# Altium schematic sheet [1]power.SchDoc([1]power)
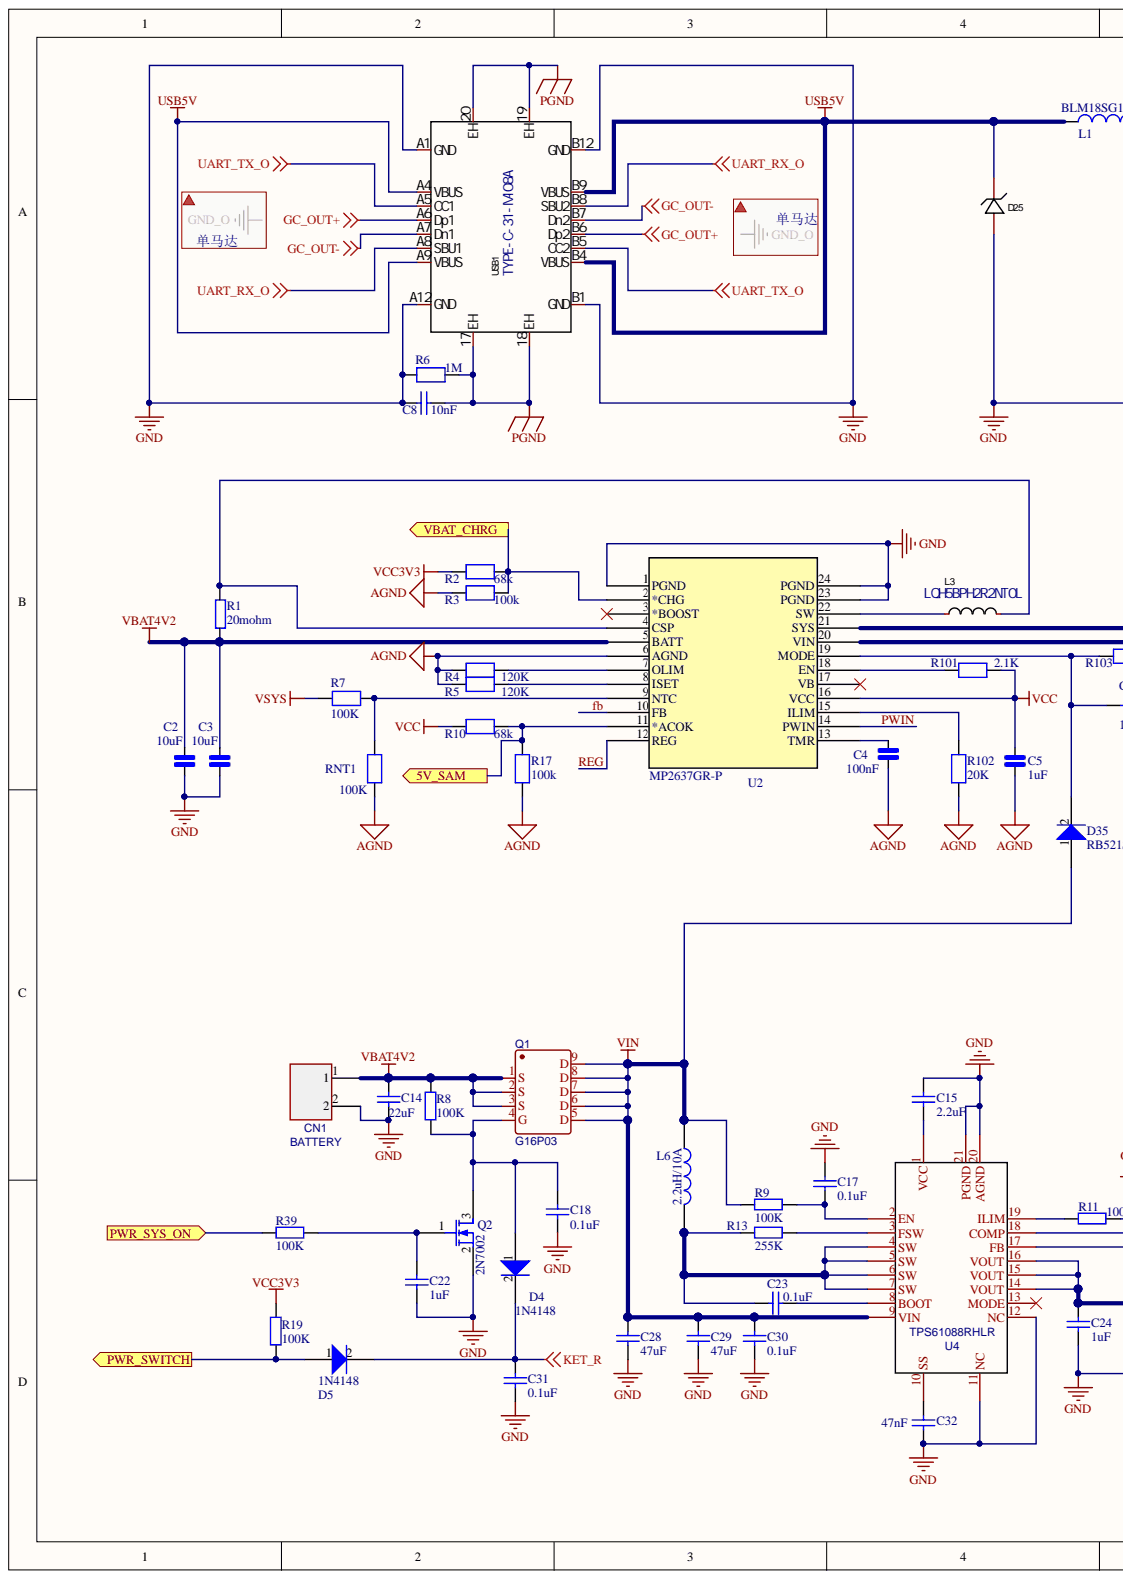
[diagram p0: [1]power.SchDoc, left]
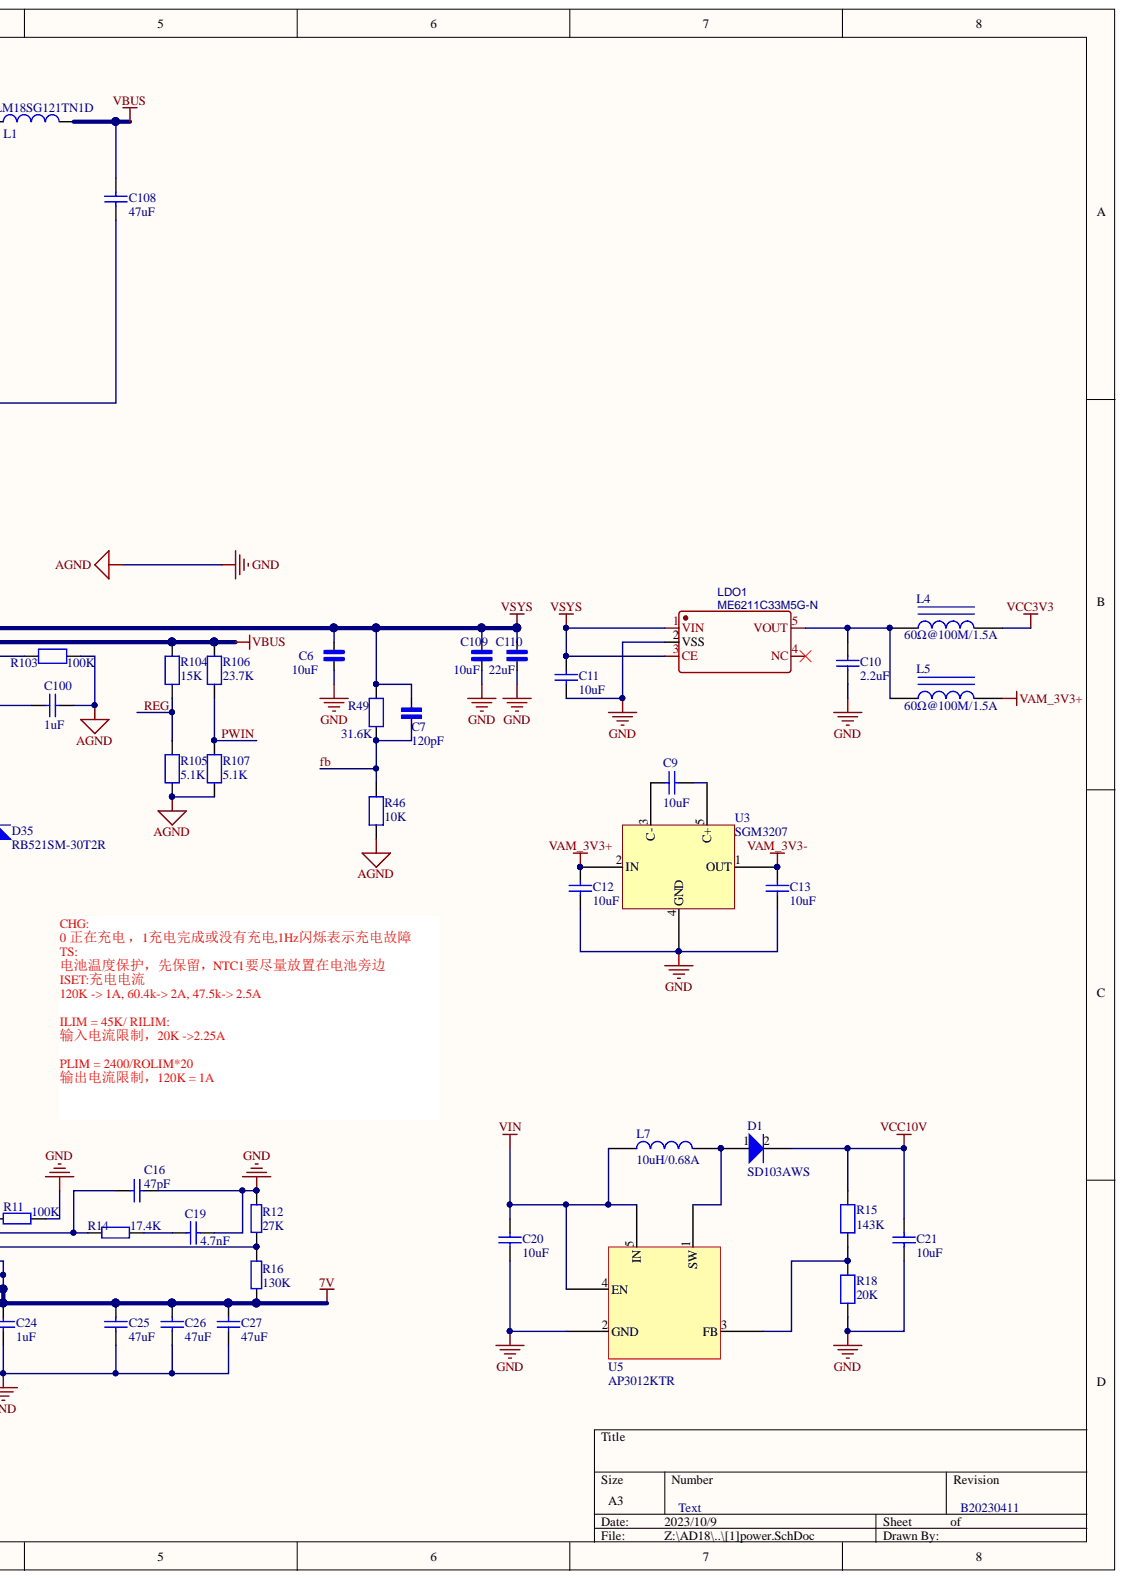
[diagram p1: [1]power.SchDoc, right]

## Parts
C2  10uF  [0603_CAP_NPOL]
C3  10uF  [0603_CAP_NPOL]
C4  100nF  [0402_CAP_NPOL]
C5  1uF  [0402_CAP_NPOL]
C6  10uF  [0603_CAP_NPOL]
C7  120pF  [0402_CAP_NPOL]
C8  10nF  [C0402]
C9  10uF  [0603]
C10  2.2uF  [C0603]
C11  10uF  [C0603]
C12  10uF  [0603]
C13  10uF  [0603]
C14  22uF  [C0805]
C15  2.2uF  [C0603]
C16  47pF  [C0402]
C17  0.1uF  [C0402]
C18  0.1uF  [C0402]
C19  4.7nF  [C0402]
C20  10uF  [C0603]
C21  10uF  [C0603]
C22  1uF  [C0402]
C23  0.1uF  [C0402]
C24  1uF  [C0402]
C25  47uF  [C1210]
C26  47uF  [C1210]
C27  47uF  [C1210]
C28  47uF  [C1210]
C29  47uF  [C1210]
C30  0.1uF  [C0402]
C31  0.1uF  [C0402]
C32  47nF  [C0402]
C100  1uF  [C0402]
C108  47uF  [C1210]
C109  10uF  [0603_CAP_NPOL]
C110  22uF  [C0805]
CN1  BATTERY  [CONN-TH_B2B-PH-K-S]
D1  SD103AWS  [SOD-323]
D4  1N4148  [SOD523]
D5  1N4148  [SOD523]
D25  TPD1E05U06DPYR  [X2SON-2_L1.0-W0.6-P0.70-RD]  (value not drawn)
D35  RB521SM-30T2R  [SOD523]
L1  BLM18SG121TN1D  [0603L]
L3  LQH5BPH2R2NT0L  [IND-SMD_L5.0-W5.0-LQH5BPN1R5NT0L]
L4  60Ω@100M/1.5A  [L0402]
L5  60Ω@100M/1.5A  [L0402]
L6  2.2uH/10A  [MWSA0603S-2R2MT]
L7  10uH/0.68A  [2520]
LDO1  ME6211C33M5G-N  [ME6211C33M5G-N]
Q1  G16P03  [G16P03]
Q2  2N7002  [SOT-23(SOT-23-3)]
R1  20mohm  [0805]
R2  68k  [0402_RES]
R3  100k  [0402_RES]
R4  120K  [0402_RES]
R5  120K  [0402_RES]
R6  1M  [R0402]
R7  100K  [0402_RES]
R8  100K  [R0402]
R9  100K  [R0402]
R10  68k  [0402_RES]
R11  100K  [R0402]
R12  27K  [R0402]
R13  255K  [R0402]
R14  17.4K  [R0402]
R15  143K  [R0402]
R16  130K  [R0402]
R17  100k  [0402_RES]
R18  20K  [R0402]
R19  100K  [R0402]
R39  100K  [R0402]
R46  10K  [0402_RES]
R49  31.6K  [0402_RES]
R101  2.1K  [0402_RES]
R102  20K  [0402_RES]
R103  100K  [0402_RES]
R104  15K  [0402_RES]
R105  5.1K  [0402_RES]
R106  23.7K  [0402_RES]
R107  5.1K  [0402_RES]
RNT1  100K  [0402_RES]
U2  MP2637GR-P  [QFN-24_MP2637_MNP]
U3  SGM3207  [SOT-23-5]
U4  TPS61088RHLR  [TPS61088RHLR]
U5  AP3012KTR  [SOT-23-5]
USB1  TYPE-C-31-M-08A  [USB-C-SMD_TYPE-C-31-M-08A]

## Ports
5V_SAM, PWR_SWITCH, PWR_SYS_ON, VBAT_CHRG

## Nets
7V: C24.1 C25.2 C26.2 C27.2 R16.1 U4.14(VOUT) U4.15(VOUT) U4.16(VOUT)
AGND: C2.2 C3.2 C4.2 C5.2 C6.2 C8.1 C10.1 C11.1 C12.2 C13.2 C14.2 C15.2 C16.2 C17.1 C18.2 C19.2 C20.2 C21.1 C22.2 C24.2 C25.1 C26.1 C27.1 C28.1 C29.1 C30.2 C31.2 C32.2 C100.2 C108.1 C109.2 C110.2 CN1.2 D25.2 LDO1.2(VSS) Q2.2 R3.1 R4.1 R5.1 R6.2 R11.2 R12.2 R17.1 R18.1 R46.2 R102.2 R103.1 R105.2 R107.2 RNT1.1 U2.1(PGND) U2.6(AGND) U2.23(PGND) U2.24(PGND) U3.4(GND) U4.11(NC) U4.12(NC) U4.20(AGND) U4.21(PGND) U5.2(GND) USB1.A1(GND) USB1.A12(GND) USB1.B1(GND) USB1.B12(GND)
GC_OUT+: USB1.A6(Dp1) USB1.B6(Dp2)
GC_OUT-: USB1.A7(Dn1) USB1.B7(Dn2)
KET_R: C31.1 D4.2 D5.2
PGND: C8.2 R6.1 USB1.17(EH) USB1.18(EH) USB1.19(EH) USB1.20(EH)
UART_RX_O: USB1.A8(SBU1) USB1.B8(SBU2)
UART_TX_O: USB1.A5(CC1) USB1.B5(CC2)
USB5V: D25.1 L1.1 USB1.A4(VBUS) USB1.A9(VBUS) USB1.B4(VBUS) USB1.B9(VBUS)
VAM_3V3+: C12.1 L5.2 U3.2(IN)
VAM_3V3-: C13.1 U3.1(OUT)
VBAT4V2: C2.1 C3.1 C14.1 CN1.1 Q1.1(S) Q1.2(S) Q1.3(S) R1.1 R8.2 U2.5(BATT)
VBUS: C108.2 L1.2 R104.1 R106.1 U2.20(VIN)
VCC: C5.1 R10.1 R101.2 U2.16(VCC)
VCC3V3: L4.2 R2.1 R19.2
VCC10V: C21.2 D1.2 R15.2
VIN: C20.1 C28.2 C29.2 C30.1 D35.1 L6.2 L7.2 Q1.5(D) Q1.6(D) Q1.7(D) Q1.8(D) Q1.9(D) R9.1 U4.9(VIN) U5.4(EN) U5.5(IN)
VSYS: C6.1 C7.2 C11.2 C109.1 C110.1 LDO1.1(VIN) LDO1.3(CE) R7.2 R49.1 U2.21(SYS)
5V_SAM: R10.2 R17.2 U2.11(*ACOK) ; port 5V_SAM
fb: C7.1 R46.1 R49.2 U2.10(FB)
PWIN: R106.2 R107.1 U2.14(PWIN)
PWR_SWITCH: D5.1 R19.1 ; port PWR_SWITCH
PWR_SYS_ON: R39.1 ; port PWR_SYS_ON
REG: R104.2 R105.1 U2.12(REG)
VBAT_CHRG: R2.2 R3.2 U2.2(*CHG) ; port VBAT_CHRG
NetC4_1: C4.1 U2.13(TMR)
NetC9_1: C9.1 U3.3(C-)
NetC9_2: C9.2 U3.5(C+)
NetC10_2: C10.2 L4.1 L5.1 LDO1.5(VOUT)
NetC15_1: C15.1 U4.1(VCC)
NetC16_1: C16.1 R14.1 U4.18(COMP)
NetC17_2: C17.2 R9.2 U4.2(EN)
NetC18_1: C18.1 D4.1 Q1.4(G) Q2.3 R8.1
NetC19_1: C19.1 R14.2
NetC22_1: C22.1 Q2.1 R39.2
NetC23_1: C23.1 L6.1 R13.1 U4.4(SW) U4.5(SW) U4.6(SW) U4.7(SW)
NetC23_2: C23.2 U4.8(BOOT)
NetC32_1: C32.1 U4.10(SS)
NetC100_1: C100.1 D35.2 R103.2 U2.19(MODE)
NetD1_1: D1.1 L7.1 U5.1(SW)
NetL3_1: L3.1 U2.22(SW)
NetL3_2: L3.2 R1.2 U2.4(CSP)
NetR4_2: R4.2 U2.7(OLIM)
NetR5_2: R5.2 U2.8(ISET)
NetR7_1: R7.1 RNT1.2 U2.9(NTC)
NetR11_1: R11.1 U4.19(ILIM)
NetR12_1: R12.1 R16.2 U4.17(FB)
NetR13_2: R13.2 U4.3(FSW)
NetR15_1: R15.1 R18.2 U5.3(FB)
NetR101_1: R101.1 U2.18(EN)
NetR102_1: R102.1 U2.15(ILIM)

Not connected: LDO1 4(NC); U2 3(*BOOST) 17(VB); U4 13(MODE)

## Library data of the parts
C2-C7 C109 C110 = CAP_NPOL: Capacitor_not polarized; Library Name: MB1297 revC.IntLib; Component Kind: Standard
C8 = 0603 10uF: 贴片电容 10% X7R 250V; ManufacturerNumber: CL05B104KO5NNND; Manufacturer: SAMSUNG; SuppliersPartNumber: C134153; Library Name: Cap.SchLib; Component Kind: Standard
C9 C12 C13 = 0603 10uF (1: 贴片电容 20% X5R 10V; ManufacturerNumber: CL10A106MP8NNNC; Manufacturer: SAMSUNG; SuppliersPartNumber: C85713; Library Name: Cap.SchLib; Component Kind: Standard
C10 C15 = (no symbol name): Capacitor, MLCC, 2.2uF, 16V, X5R, 10%, 0603, T=0.8mm; Manufacturer_Part_Number: CC0603KRX5R7BB475; Manufacturer: YAGEO; Internal_Part_Number: MCD-00009; Provided_By: subcon; Initial_Board_Reference: MB1274; Value: 2.2uF; Status: Released; Part_Type: Capacitor; Package: C0603; Library Name: mb1367.IntLib; ECCN_US: n/a; ECCN_EU: n/a; ComponentLink1URL: http://tunmcdsvn.tun.st.com/Component_Datasheet_Library/Trunk/Capacitors/Yageo_Multilayer_capacitor_X5R_series_100pF_to_100uF; ComponentLink1Description: Yageo_Capacitor_X5R-series.pdf; Component Kind: Standard
C11 C20 = (no symbol name): Capacitor, MLCC, 10uF, 16V, X5R, 10%, 0603, T=0.8mm; Manufacturer_Part_Number: CC0603KRX5R7BB475; Manufacturer: YAGEO; Internal_Part_Number: MCD-00009; Provided_By: subcon; Initial_Board_Reference: MB1274; Value: 10uF; Status: Released; Part_Type: Capacitor; Package: C0603; Library Name: mb1367.IntLib; ECCN_US: n/a; ECCN_EU: n/a; ComponentLink1URL: http://tunmcdsvn.tun.st.com/Component_Datasheet_Library/Trunk/Capacitors/Yageo_Multilayer_capacitor_X5R_series_100pF_to_100uF; ComponentLink1Description: Yageo_Capacitor_X5R-series.pdf; Component Kind: Standard
C14 C16-C19 C22-C24 C30-C32 C100 = 0603 10uF: 贴片电容 10% X7R 16V; ManufacturerNumber: CL05B104KO5NNND; Manufacturer: SAMSUNG; SuppliersPartNumber: C134153; Library Name: Cap.SchLib; Component Kind: Standard
C21 = (no symbol name): Capacitor, MLCC, 10uF, 25V, X5R, 10%, 0603, T=0.8mm; Manufacturer_Part_Number: CC0603KRX5R7BB475; Manufacturer: YAGEO; Internal_Part_Number: MCD-00009; Provided_By: subcon; Initial_Board_Reference: MB1274; Value: 10uF; Status: Released; Part_Type: Capacitor; Package: C0603; Library Name: mb1367.IntLib; ECCN_US: n/a; ECCN_EU: n/a; ComponentLink1URL: http://tunmcdsvn.tun.st.com/Component_Datasheet_Library/Trunk/Capacitors/Yageo_Multilayer_capacitor_X5R_series_100pF_to_100uF; ComponentLink1Description: Yageo_Capacitor_X5R-series.pdf; Component Kind: Standard
C25-C29 C108 = Cap Semi: mlcc 16v; Value: 47uF; Simulation: CAP; Signal Integrity: Cap Semi; Published: 8-Jun-2000; PackageReference: C1206; PackageDescription: Chip Capacitor; Library Name: Miscellaneous Devices.IntLib; LatestRevisionNote: IPC-7351 Footprint Added.; LatestRevisionDate: 29-May-2009; Component Kind: Standard; Publisher: Altium Limited
CN1 = B2B-PH-K-S(LF)(SN): BOM_Manufacturer: JST; Contributor: LCSC; spiceSymbolName: B2B-PH-K-S(LF)(SN); spicePre: C; Component Kind: Standard; BOM_Supplier Part: C131337; BOM_Supplier: LCSC; BOM_Manufacturer Part: B2B-PH-K-S(LF)(SN); BOM_JLCPCB Part Class: Extended Part
D1 = SOD-123: 肖特基二极管 40V,0.35A,VF=0.6V@0.2A; ManufacturerNumber: SD103AWS 21; Manufacturer: CJ江苏长电; SuppliersPartNumber: C8607; Library Name: Diode.SchLib; Component Kind: Standard
D4 D5 = SO: 开关二极管 75V 150mA trr=4ns VF=1.25V@150mA PD=400mW; ManufacturerNumber: 1N4148WT; Manufactu: CJ江苏长电; SuppliersPartNumber: C68948; Library Name: Diode.SchLib; Component Kind: Standard
D25 = TPD1E05U06DPYR: TVS 单向   反向截止电压(Vrwm)5.5V@Max  击穿电压6V  峰值脉冲电流(Ipp)@10/1000μs  2.5A@8/20us   最大钳位电压14V; Manufacturer: TI(德州仪器); Maximum Clamping Voltage: 14V; Convert to PCB: yes; Breakdown Voltage: 6V; Symbol: TPD1E05U06DPYR; Supplier Part: C436349; Supplier Footprint: X2-SON-2(0.6x1); Supplier: LCSC; Reverse Stand-Off Voltage (Vrwm): 5.5V@Max; Polarity: 单向; Peak Pulse Current (Ipp)@10/1000μs: 2.5A@8/20us; PCB3D: X2SON-2_L1.0-W0.6-H0.4-P0.70-RD; Name: TPD1E05U06DPYR; Manufacturer Part: TPD1E05U06DPYR; Library Name: HiPin_Library_AD.IntLib; LCSC Part Name: 单向 Vrwm:5.5V@Max; JLCPCB Part Class: Extended Part; Device: TPD1E05U06DPYR; Datasheet: https://atta.szlcsc.com/upload/public/pdf/source/20191016/C436349_486749D4EDA8974C8ED360A45B982565.pdf; Component Kind: Standard; Add into BOM: yes
D35 = SO: 肖特基30V, 200mA,VF=0.47V@200mA; ManufacturerNumber: 1N4148WT; Manufactu: CJ江苏长电; SuppliersPartNumber: C68948; Library Name: Diode.SchLib; Component Kind: Standard
L1 = Inductor: 120mΩ   额定电流 3A; Value: 100uH; Revision: July-2002: Re-released for DXP Platform.; Published: 8-Jun-2000; Library Name: HiPin_Library_AD.IntLib; Component Kind: Standard; Publisher: Altium Limited
L3 = LQH5BPH2R2NT0L: Manufacturer: muRata(村田); Value: 2.2uH; Convert to PCB: yes; Symbol: LQH5BPH2R2NT0L; Supplier Part: C2046542; Supplier Footprint: -; Supplier: LCSC; PCB3D: IND-SMD_L5.0-W5.0-LQH5BPN1R5NT0L; Name: LQH5BPH2R2NT0L; Manufacturer Part: LQH5BPH2R2NT0L; Library Name: HiPin_Library_AD.IntLib; LCSC Part Name: LQH5BPH2R2NT0L; JLCPCB Part Class: Extended Part; Device: LQH5BPH2R2NT0L; Datasheet: https://atta.szlcsc.com/upload/public/pdf/source/20230811/52C354BF80095239F52F80FEC1CE0BBB.pdf; Component Kind: Standard; Add into BOM: yes
L4 = 1206: 贴片磁珠 60Ω ±25% @100MHz 1.5A; ManufacturerNumber: UPZ1005D600-1R5TF; Manufacturer: Sunlord; SuppliersPartNumber: C98300; Library Name: IUD.SchLib; Component Kind: Standard
L5 = 1206 4.7uH: 贴片磁珠 60Ω ±25% @100MHz 1.5A; ManufacturerNumber: UPZ1005D600-1R5TF; Manufacturer: Sunlord; SuppliersPartNumber: C98300; Library Name: IUD.SchLib; Component Kind: Standard
L6 = INDUCTOR: ManufacturerNumber: SWPA252012S100MT; Manufacturer: Sunlord; SuppliersPartNumber: C37428; Description: 2.2uH/10A; Component Kind: Standard
L7 = INDUCTOR: 功率电感 10uH ±20% 680mA; ManufacturerNumber: SWPA252012S100MT; Manufacturer: Sunlord; SuppliersPartNumber: C37428; Component Kind: Standard
LDO1 = ME6211C33M5G-N: BOM_Manufacturer: MICRONE; spiceSymbolName: ME6211C33M5G-N; spicePre: L; Component Kind: Standard; BOM_Supplier Part: C82942; BOM_Supplier: LCSC; BOM_Manufacturer Part: ME6211C33M5G-N; BOM_JLCPCB Part Class: Extended Part
Q1 = G16P03: BOM_Manufacturer: GOFORD; Contributor: LCSC; spiceSymbolName: G16P03; spicePre: Q; Component Kind: Standard; BOM_Supplier Part: C193982; BOM_Supplier: LCSC; BOM_Manufacturer Part: G16P03; BOM_JLCPCB Part Class: Extended Part
Q2 = MOSFET-N: NMOS 60V 0.115A 7Ω@10V; Manufacturer: CJ江苏长电; SuppliersPartNumber: C8545; ManufacturerNumber: 2N7002; Library Name: Miscellaneous Devices.IntLib; Component Kind: Standard
R1 = R: Library Name: ATK-MD0700R.IntLib; Component Kind: Standard
R2-R7 R10 R15 R17 R18 R46 R49 R101-R107 RNT1 = RES: Resistor; Component Kind: Standard
  R2 R3 R6 R10 R15 R17 R18: Library Name: MB1297 revC.IntLib
  R4 R5 R7 R46 R49 R101-R107 RNT1: Library Name: HiPin_Library_AD.IntLib
R8 R19 = 060: 贴片电阻 1% 1/16W 200ppm; ManufacturerNumber: RC0402FR-07100KL; Manufacturer: YAGEO; SuppliersPartNumber: C60491; Library Name: RES.SchLib; Component Kind: Standard
R9 R11-R14 R16 = 060: 贴片电阻 1% 1/16W 100ppm; ManufacturerNumber: RC0402FR-07100KL; Manufacturer: YAGEO; SuppliersPartNumber: C60491; Library Name: RES.SchLib; Component Kind: Standard
R39 = 060: 贴片电阻 1% 1/16W 200ppm; ManufacturerNumber: RC0402FR-07143KL; Manufacturer: YAGEO; SuppliersPartNumber: C375492; Library Name: RES.SchLib; Component Kind: Standard
U2 = MP2637GR-P: No Description Available; Mfr_Name: Monolithic Power Systems; Type: DEV; RefDes: RefDes; Manufacturer_Part_Number: MP2637GR-P; Library Name: HiPin_Library_AD.IntLib; Component Kind: Standard; Copyright: Copyright (C) 2023 Ultra Librarian. All rights reserved.
U3 = SGM: 电压反向器 19kHz 60mA; ManufacturerNumber: SGM3207YN5G/TR; Manufacturer: SGMICRO; SuppliersPartNumber: C80403; Library Name: user.SchLib; Component Kind: Standard
U4 = TPS61088RHLR: BOM_Manufacturer: TI; spiceSymbolName: TPS61088RHLR; spicePre: U; Component Kind: Standard; BOM_Supplier Part: C87357; BOM_Supplier: LCSC; BOM_Manufacturer Part: TPS61088RHLR; BOM_JLCPCB Part Class: Extended Part
U5 = MP1540: 升压转换器; ManufacturerNumber: MP1540DJ-LF-Z; Manufacturer: MPS; SuppliersPartNumber: C15036; Library Name: bm190409.SCHLIB; Component Kind: Standard
USB1 = TYPE-C-31-M-08A: Manufacturer: 韩国韩荣; Number of Ports: 1; Number of Contacts: 16; Gender: 母座; Current Rating - Power (Max): 2A; Convert to PCB: yes; Connector Type: Type-C; Symbol: TYPE-C-31-M-08A; Supplier Part: C2848616; Supplier Footprint: SMD; Supplier: LCSC; Standard: -; PCB3D: USB-C-SMD_TYPE-C-31-M-08A; Operating Temperature Range: -30℃~+80℃; Name: TYPE-C-31-M-08A; Mounting Style: 卧贴; Manufacturer Part: TYPE-C-31-M-08A; Library Name: HiPin_Library_AD.IntLib; LCSC Part Name: Type-C 母座 卧贴; JLCPCB Part Class: Extended Part; Device: TYPE-C-31-M-08A; Datasheet: https://atta.szlcsc.com/upload/public/pdf/source/20210810/9362338FA044DF7988FF1033987C53F2.pdf; Component Kind: Standard; Add into BOM: yes
